# Altium schematic sheet PERIPHERAL_PORT_IO.SchDoc
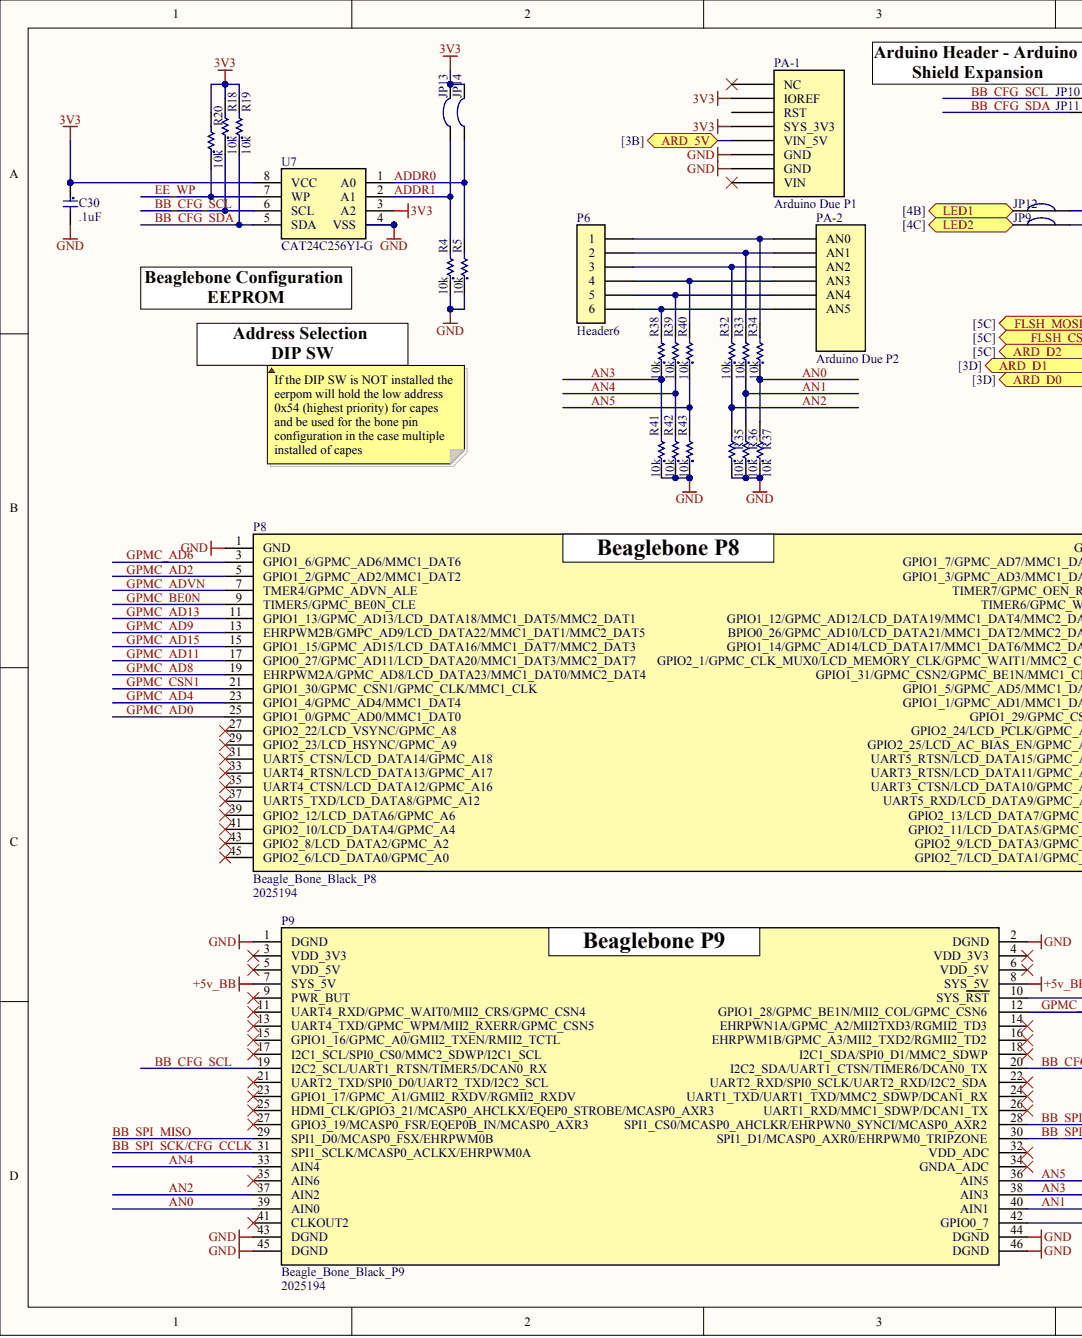
[diagram p0: PERIPHERAL_PORT_IO.SchDoc, left]
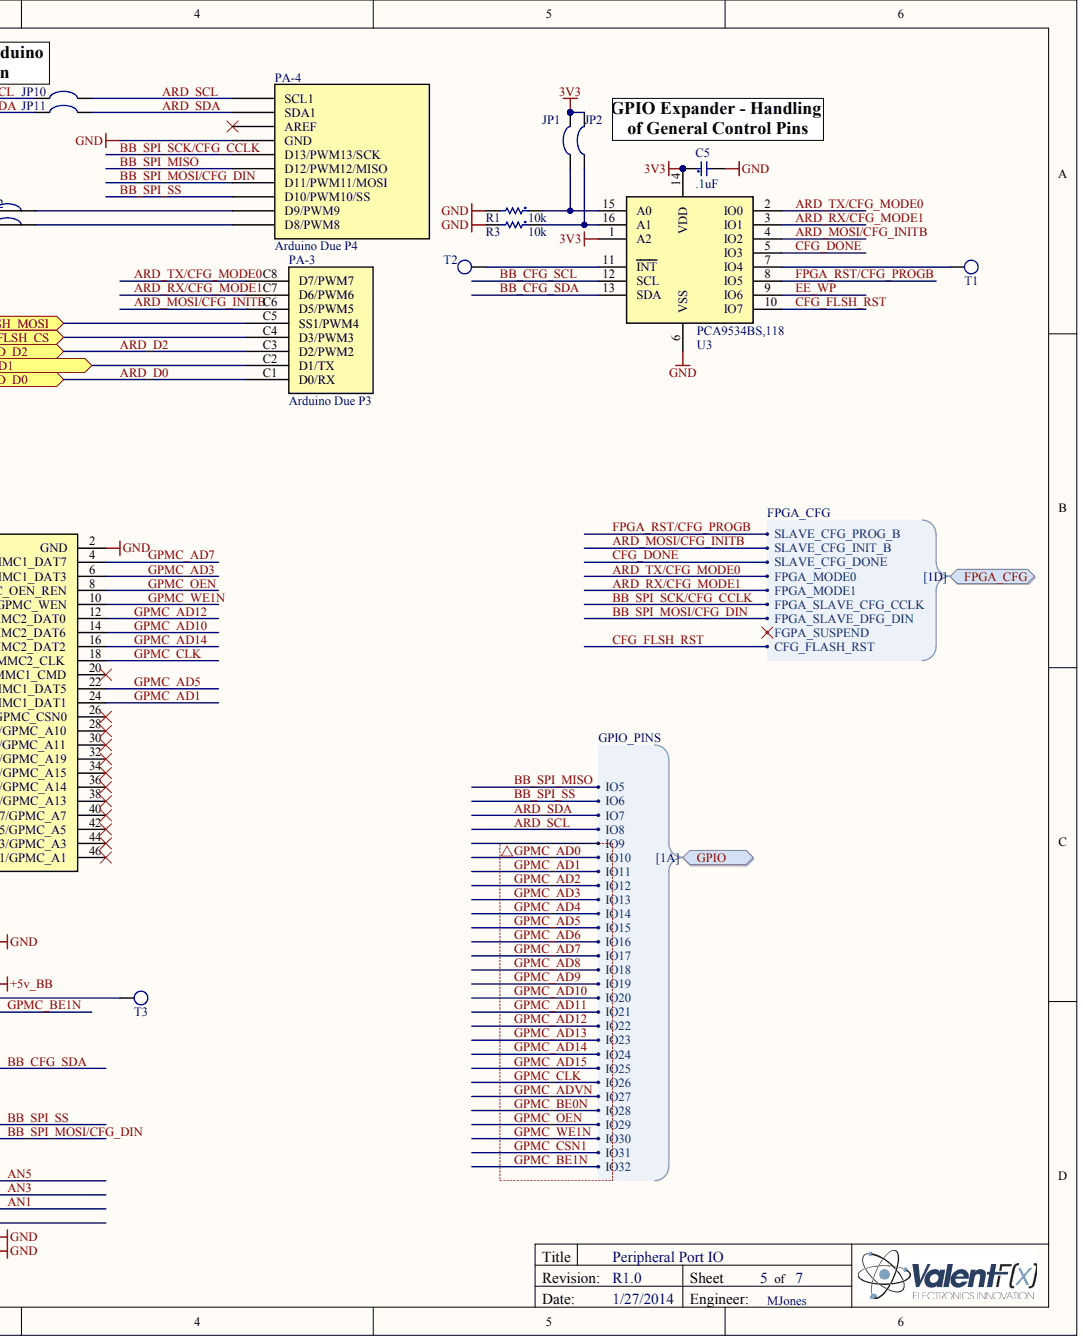
[diagram p1: PERIPHERAL_PORT_IO.SchDoc, right]

## Parts
C5  .1uF
C30  .1uF
JP1  Solder Jumper  (value not drawn)
JP2  Solder Jumper  (value not drawn)
JP9  Solder Jumper-NC  (value not drawn)
JP10  Solder Jumper  (value not drawn)
JP11  Solder Jumper  (value not drawn)
JP12  Solder Jumper-NC  (value not drawn)
JP13  Solder Jumper  (value not drawn)
JP14  Solder Jumper  (value not drawn)
P6  Header6
P8  Supplier Part Number 1: 2025194  (hidden comment: Beagle_Bone_Black_P8)
P9  Supplier Part Number 1: 2025194  (hidden comment: Beagle_Bone_Black_P9)
PA-1  Arduino Due P1
PA-2  Arduino Due P2
PA-3  Arduino Due P3
PA-4  Arduino Due P4
R1  10k
R3  10k
R4  10k
R5  10k
R18  10k
R19  10k
R20  10k
R32  10k
R33  10k
R34  10k
R35  10k
R36  10k
R37  10k
R38  10k
R39  10k
R40  10k
R41  10k
R42  10k
R43  10k
T1  Test Point  (value not drawn)
T2  Test Point  (value not drawn)
T3  Test Point  (value not drawn)
U3  PCA9534BS,118
U7  CAT24C256YI-G

## Ports
ARD_5V, ARD_D0, ARD_D1, ARD_D2, FLSH_CS, FLSH_MOSI, FPGA_CFG, FPGA_CFG.CFG_FLASH_RST, FPGA_CFG.FGPA_SUSPEND, FPGA_CFG.FPGA_MODE0, FPGA_CFG.FPGA_MODE1, FPGA_CFG.FPGA_SLAVE_CFG_CCLK, FPGA_CFG.FPGA_SLAVE_DFG_DIN, FPGA_CFG.SLAVE_CFG_DONE, FPGA_CFG.SLAVE_CFG_INIT_B, FPGA_CFG.SLAVE_CFG_PROG_B, GPIO, GPIO.IO5, GPIO.IO6, GPIO.IO7, GPIO.IO8, GPIO.IO9, GPIO.IO10, GPIO.IO11, GPIO.IO12, GPIO.IO13, GPIO.IO14, GPIO.IO15, GPIO.IO16, GPIO.IO17, GPIO.IO18, GPIO.IO19, GPIO.IO20, GPIO.IO21, GPIO.IO22, GPIO.IO23, GPIO.IO24, GPIO.IO25, GPIO.IO26, GPIO.IO27, GPIO.IO28, GPIO.IO29, GPIO.IO30, GPIO.IO31, GPIO.IO32, LED1, LED2

## Nets
3V3: C5.1 C30.1 JP1.2 JP2.2 JP13.2 JP14.2 PA-1.A2(IOREF) PA-1.A4(SYS_3V3) R18.2 R19.2 R20.2 U3.1(A2) U3.14(VDD) U7.3(A2) U7.8(VCC)
+5V_BB: P9.7(SYS_5V) P9.8(SYS_5V)
GND: C5.2 C30.2 P8.1(GND) P8.2(GND) P9.1(DGND) P9.2(DGND) P9.43(DGND) P9.44(DGND) P9.45(DGND) P9.46(DGND) PA-1.A6(GND) PA-1.A7(GND) PA-4.D7(GND) R1.2 R3.2 R4.1 R5.1 R35.1 R36.1 R37.1 R41.1 R42.1 R43.1 U3.6(VSS) U7.4(VSS)
ADDR0: JP14.1 R5.2 U7.1(A0)
ADDR1: JP13.1 R4.2 U7.2(A1)
AN0: P9.39(AIN0) R34.1 R37.2
AN1: P9.40(AIN1) R33.1 R36.2
AN2: P9.37(AIN2) R32.1 R35.2
AN3: P9.38(AIN3) R38.1 R41.2
AN4: P9.33(AIN4) R39.1 R42.2
AN5: P9.36(AIN5) R40.1 R43.2
ARD_5V: PA-1.A5(VIN_5V) ; port ARD_5V
ARD_D0: PA-3.C1(D0/RX) ; port ARD_D0
ARD_D1: PA-3.C2(D1/TX) ; port ARD_D1
ARD_D2: PA-3.C3(D2/PWM2) ; port ARD_D2
ARD_MOSI/CFG_INITB: PA-3.C6(D5/PWM5) U3.4(IO2) ; port FPGA_CFG
ARD_RX/CFG_MODE1: PA-3.C7(D6/PWM6) U3.3(IO1) ; port FPGA_CFG
ARD_SCL: JP10.2 PA-4.D10(SCL1) ; port GPIO
ARD_SDA: JP11.2 PA-4.D9(SDA1) ; port GPIO
ARD_TX/CFG_MODE0: PA-3.C8(D7/PWM7) U3.2(IO0) ; port FPGA_CFG
BB_CFG_SCL: JP10.1 P9.19(I2C2_SCL/UART1_RTSN/TIMER5/DCAN0_RX) R18.1 U3.12(SCL) U7.6(SCL)
BB_CFG_SDA: JP11.1 P9.20(I2C2_SDA/UART1_CTSN/TIMER6/DCAN0_TX) R19.1 U3.13(SDA) U7.5(SDA)
BB_SPI_MISO: P9.29(SPI1_D0/MCASP0_FSX/EHRPWM0B) PA-4.D5(D12/PWM12/MISO) ; port GPIO
BB_SPI_MOSI/CFG_DIN: P9.30(SPI1_D1/MCASP0_AXR0/EHRPWM0_TRIPZONE) PA-4.D4(D11/PWM11/MOSI) ; port FPGA_CFG
BB_SPI_SCK/CFG_CCLK: P9.31(SPI1_SCLK/MCASP0_ACLKX/EHRPWM0A) PA-4.D6(D13/PWM13/SCK) ; port FPGA_CFG
BB_SPI_SS: P9.28(SPI1_CS0/MCASP0_AHCLKR/EHRPWN0_SYNCI/MCASP0_AXR2) PA-4.D3(D10/PWM10/SS) ; port GPIO
CFG_DONE: U3.5(IO3) ; port FPGA_CFG
CFG_FLSH_RST: U3.10(IO7) ; port FPGA_CFG
EE_WP: R20.1 U3.9(IO6) U7.7(WP)
FLSH_CS: PA-3.C4(D3/PWM3) ; port FLSH_CS
FLSH_MOSI: PA-3.C5(SS1/PWM4) ; port FLSH_MOSI
FPGA_RST/CFG_PROGB: U3.8(IO5) ; port FPGA_CFG
GPMC_AD0: P8.25(GPIO1_0/GPMC_AD0/MMC1_DAT0) ; port GPIO
GPMC_AD1: P8.24(GPIO1_1/GPMC_AD1/MMC1_DAT1) ; port GPIO
GPMC_AD2: P8.5(GPIO1_2/GPMC_AD2/MMC1_DAT2) ; port GPIO
GPMC_AD3: P8.6(GPIO1_3/GPMC_AD3/MMC1_DAT3) ; port GPIO
GPMC_AD4: P8.23(GPIO1_4/GPMC_AD4/MMC1_DAT4) ; port GPIO
GPMC_AD5: P8.22(GPIO1_5/GPMC_AD5/MMC1_DAT5) ; port GPIO
GPMC_AD6: P8.3(GPIO1_6/GPMC_AD6/MMC1_DAT6) ; port GPIO
GPMC_AD7: P8.4(GPIO1_7/GPMC_AD7/MMC1_DAT7) ; port GPIO
GPMC_AD8: P8.19(EHRPWM2A/GPMC_AD8/LCD_DATA23/MMC1_DAT0/MMC2_DAT4) ; port GPIO
GPMC_AD9: P8.13(EHRPWM2B/GMPC_AD9/LCD_DATA22/MMC1_DAT1/MMC2_DAT5) ; port GPIO
GPMC_AD10: P8.14(BPIO0_26/GPMC_AD10/LCD_DATA21/MMC1_DAT2/MMC2_DAT6) ; port GPIO
GPMC_AD11: P8.17(GPIO0_27/GPMC_AD11/LCD_DATA20/MMC1_DAT3/MMC2_DAT7) ; port GPIO
GPMC_AD12: P8.12(GPIO1_12/GPMC_AD12/LCD_DATA19/MMC1_DAT4/MMC2_DAT0) ; port GPIO
GPMC_AD13: P8.11(GPIO1_13/GPMC_AD13/LCD_DATA18/MMC1_DAT5/MMC2_DAT1) ; port GPIO
GPMC_AD14: P8.16(GPIO1_14/GPMC_AD14/LCD_DATA17/MMC1_DAT6/MMC2_DAT2) ; port GPIO
GPMC_AD15: P8.15(GPIO1_15/GPMC_AD15/LCD_DATA16/MMC1_DAT7/MMC2_DAT3) ; port GPIO
GPMC_ADVN: P8.7(TMER4/GPMC_ADVN_ALE) ; port GPIO
GPMC_BE0N: P8.9(TIMER5/GPMC_BE0N_CLE) ; port GPIO
GPMC_BE1N: P9.12(GPIO1_28/GPMC_BE1N/MII2_COL/GPMC_CSN6) ; port GPIO
GPMC_CLK: P8.18(GPIO2_1/GPMC_CLK_MUX0/LCD_MEMORY_CLK/GPMC_WAIT1/MMC2_CLK) ; port GPIO
GPMC_CSN1: P8.21(GPIO1_30/GPMC_CSN1/GPMC_CLK/MMC1_CLK) ; port GPIO
GPMC_OEN: P8.8(TIMER7/GPMC_OEN_REN) ; port GPIO
GPMC_WE1N: P8.10(TIMER6/GPMC_WEN) ; port GPIO
LED1: JP12.1 ; port LED1
LED2: JP9.1 ; port LED2
NetJP1_1: JP1.1 R1.1 U3.15(A0)
NetJP2_1: JP2.1 R3.1 U3.16(A1)
NetJP9_2: JP9.2 PA-4.D1(D8/PWM8)
NetJP12_2: JP12.2 PA-4.D2(D9/PWM9)
NetP6_1: P6.1 PA-2.B1(AN0) R34.2
NetP6_2: P6.2 PA-2.B2(AN1) R33.2
NetP6_3: P6.3 PA-2.B3(AN2) R32.2
NetP6_4: P6.4 PA-2.B4(AN3) R40.2
NetP6_5: P6.5 PA-2.B5(AN4) R39.2
NetP6_6: P6.6 PA-2.B6(AN5) R38.2
NetP9_10: P9.10(SYS_RST) T3.1
NetT1_1: T1.1 U3.7(IO4)
NetT2_1: T2.1 U3.11(INT)
N00220: ; port FPGA_CFG
N00221: ; port GPIO

Not connected: P8 20(GPIO1_31/GPMC_CSN2/GPMC_BE1N/MMC1_CMD) 26(GPIO1_29/GPMC_CSN0) 27(GPIO2_22/LCD_VSYNC/GPMC_A8) 28(GPIO2_24/LCD_PCLK/GPMC_A10) 29(GPIO2_23/LCD_HSYNC/GPMC_A9) 30(GPIO2_25/LCD_AC_BIAS_EN/GPMC_A11) 31(UART5_CTSN/LCD_DATA14/GPMC_A18) 32(UART5_RTSN/LCD_DATA15/GPMC_A19) 33(UART4_RTSN/LCD_DATA13/GPMC_A17) 34(UART3_RTSN/LCD_DATA11/GPMC_A15) 35(UART4_CTSN/LCD_DATA12/GPMC_A16) 36(UART3_CTSN/LCD_DATA10/GPMC_A14) 37(UART5_TXD/LCD_DATA8/GPMC_A12) 38(UART5_RXD/LCD_DATA9/GPMC_A13) 39(GPIO2_12/LCD_DATA6/GPMC_A6) 40(GPIO2_13/LCD_DATA7/GPMC_A7) 41(GPIO2_10/LCD_DATA4/GPMC_A4) 42(GPIO2_11/LCD_DATA5/GPMC_A5) 43(GPIO2_8/LCD_DATA2/GPMC_A2) 44(GPIO2_9/LCD_DATA3/GPMC_A3) 45(GPIO2_6/LCD_DATA0/GPMC_A0) 46(GPIO2_7/LCD_DATA1/GPMC_A1); P9 3(VDD_3V3) 4(VDD_3V3) 5(VDD_5V) 6(VDD_5V) 9(PWR_BUT) 11(UART4_RXD/GPMC_WAIT0/MII2_CRS/GPMC_CSN4) 13(UART4_TXD/GPMC_WPM/MII2_RXERR/GPMC_CSN5) 14(EHRPWN1A/GPMC_A2/MII2TXD3/RGMII2_TD3) 15(GPIO1_16/GPMC_A0/GMII2_TXEN/RMII2_TCTL) 16(EHRPWM1B/GPMC_A3/MII2_TXD2/RGMII2_TD2) 17(I2C1_SCL/SPI0_CS0/MMC2_SDWP/I2C1_SCL) 18(I2C1_SDA/SPI0_D1/MMC2_SDWP) 21(UART2_TXD/SPI0_D0/UART2_TXD/I2C2_SCL) 22(UART2_RXD/SPI0_SCLK/UART2_RXD/I2C2_SDA) 23(GPIO1_17/GPMC_A1/GMII2_RXDV/RGMII2_RXDV) 24(UART1_TXD/UART1_TXD/MMC2_SDWP/DCAN1_RX) 25(HDMI_CLK/GPIO3_21/MCASP0_AHCLKX/EQEP0_STROBE/MCASP0_AXR3) 26(UART1_RXD/MMC1_SDWP/DCAN1_TX) 27(GPIO3_19/MCASP0_FSR/EQEP0B_IN/MCASP0_AXR3) 32(VDD_ADC) 34(GNDA_ADC) 35(AIN6) 41(CLKOUT2) 42(GPIO0_7); PA-1 A1(NC) A3(RST) A8(VIN); PA-4 D8(AREF)

## Library data of the parts
C5 C30 = (no symbol name): Manufacturer: Murata; Manufacturer Part Number: GRM155R61C104KA88D; Capacitance: 0.1 uF; Tolerance: 10 %; Voltage Rating: 16 Volts; Temperature Coefficient / Code: X5R; Value: .1uF; Supplier 1: Mouser; Supplier Part Number 1: 81-GRM155R61C104KA8D; RoHS: RoHS Compliant By Exemption; Category: Multilayer Ceramic Capacitors (MLCC) - SMD/SMT; Stock 1: 478408; Pricing 1: 1=0.122, 50=0.034, 100=0.026, 500=0.014, 1000=0.009, 10000=0.005, 20000=0.004 (AUD); Package / Case: 0402 (1005 metric); Packaging: Reel; ComponentLink1URL: http://www.murata.com/products/catalog/pdf/c02e.pdf; ComponentLink1Description: http://www.murata.com/products/catalog/pdf/c02e.pdf; Dimensions: 0.5 mm W x 1 mm L x 0.5 mm H; Operating Temperature Range: - 55 C to + 85 C; Product: General Type MLCCs; Series: GRM; Termination Style: SMD/SMT; Product Category: Low & Medium Capacitance SMD Ceramic Chip Capacitors; Standard Pack Qty: 10000; RoHS - Mouser: E; Minimum Order Quantity: 1; Reeling: True
P8 P9 = (no symbol name): Manufacturer: SAMTEC; Manufacturer Part Number: TSW-123-07-F-D; Pitch Spacing: 2.54; No. of Rows: 2; No. of Contacts: 46; Gender: Header; Contact Termination: Through Hole Vertical; SVHC: No SVHC (20-Jun-2013); Connector Type: Board to Board; : TSW-113-F-D; Supplier 1: Farnell; RoHS: YES; Stock 1: 100; Pricing 1: 5=3.7, 50=2.55, 100=1.99, 250=1.63, 500=1.48, 2500=1.2 (GBP); Series: TSW; Contact Plating: Gold; Contact Material: Phosphor Bronze; Row Pitch: 2.54; ComponentLink1URL: http://www.farnell.com/datasheets/1701645.pdf; ComponentLink1Description: http://www.farnell.com/datasheets/1701645.pdf; ComponentLink2URL: http://www.samtec.com/ftppub/pdf/TSW_TH.PDF; ComponentLink2Description: http://www.samtec.com/ftppub/pdf/TSW_TH.PDF; ComponentLink3URL: http://www.farnell.com/cad/1528871.zip; ComponentLink3Description: http://www.farnell.com/cad/1528871.zip
  P8: Value: Beagle_Bone_Black_P8
  P9: Value: Beagle_Bone_Black_P9
PA-1 PA-3 = (no symbol name): Manufacturer: Sullins Connector Solutions; Manufacturer Part Number: PPPC081LFBN-RC; Connector Type: Header; Number of Positions: 8; Number of Positions Loaded: All; Pitch: 0.100" (2.54mm); Supplier 1: Digi-Key; RoHS: RoHS Compliant; Category: Connectors, Interconnects; Stock 1: 30245; Pricing 1: 1=0.89, 10=0.69, 25=0.6436, 50=0.585, 100=0.5265, 250=0.4446, 500=0.4212, 1000=0.3744, 2500=0.31122 (USD); Packaging: Bulk; Contact Type: Female Socket; Number of Rows: 1; Row Spacing: -; Mounting Type: Through Hole; Termination: Solder; Fastening Type: -; Features: -; Contact Finish: Gold; Contact Finish Thickness: Flash; Color: Black; ComponentLink1URL: http://www.sullinscorp.com/drawings/78_P(N)PxCxxxLFBN-RC,_10492-G.pdf; ComponentLink1Description: http://www.sullinscorp.com/drawings/78_P(N)PxCxxxLFBN-RC,_10492-G.pdf; Supplier Part Number 1: S7041-ND
PA-2 = (no symbol name): Manufacturer: Sullins Connector Solutions; Manufacturer Part Number: PPPC061LFBN-RC; Connector Type: Header; Number of Positions: 6; Number of Positions Loaded: All; Pitch: 0.100" (2.54mm); Supplier 1: Digi-Key; RoHS: RoHS Compliant; Category: Connectors, Interconnects; Stock 1: 9213; Pricing 1: 1=0.7, 10=0.546, 25=0.5088, 50=0.4626, 100=0.4163, 250=0.35152, 500=0.333, 1000=0.296, 2500=0.24605 (USD); Packaging: Bulk; Contact Type: Female Socket; Number of Rows: 1; Row Spacing: -; Mounting Type: Through Hole; Termination: Solder; Fastening Type: -; Features: -; Contact Finish: Gold; Contact Finish Thickness: Flash; Color: Black; ComponentLink1URL: http://www.sullinscorp.com/drawings/78_P(N)PxCxxxLFBN-RC,_10492-G.pdf; ComponentLink1Description: http://www.sullinscorp.com/drawings/78_P(N)PxCxxxLFBN-RC,_10492-G.pdf; Supplier Part Number 1: S7039-ND
PA-4 = (no symbol name): Manufacturer: Sullins Connector Solutions; Manufacturer Part Number: PPPC101LFBN-RC; Connector Type: Header; Number of Positions: 10; Number of Positions Loaded: All; Pitch: 0.100" (2.54mm); Supplier 1: Digi-Key; RoHS: RoHS Compliant; Category: Connectors, Interconnects; Stock 1: 5570; Pricing 1: 1=0.84, 10=0.699, 25=0.6476, 50=0.5828, 100=0.518, 250=0.45324, 500=0.4144, 1000=0.37555, 2500=0.3367 (USD); Packaging: Bulk; Contact Type: Female Socket; Number of Rows: 1; Row Spacing: -; Mounting Type: Through Hole; Termination: Solder; Fastening Type: -; Features: -; Contact Finish: Gold; Contact Finish Thickness: Flash; Color: Black; ComponentLink1URL: http://www.sullinscorp.com/drawings/78_P(N)PxCxxxLFBN-RC,_10492-G.pdf; ComponentLink1Description: http://www.sullinscorp.com/drawings/78_P(N)PxCxxxLFBN-RC,_10492-G.pdf; Supplier Part Number 1: S7043-ND
R1 R3-R5 R18-R20 R32-R43 = (no symbol name): Manufacturer: Vishay Dale; Manufacturer Part Number: CRCW060310K0FKEA; Power (Watts): 0.1W, 1/10W; Temperature Coefficient: ±100ppm/°C; Tolerance: ±1%; Value: 10k; Supplier 1: Digi-Key; RoHS: RoHS Compliant; Category: Resistors; Stock 1: 997856; Pricing 1: 10=0.081, 50=0.0436, 200=0.0251, 1000=0.01711 (USD); Resistance (Ohms): 10K; Composition: Thick Film; Features: -; Size / Dimension: 0.061" L x 0.033" W (1.55mm x 0.85mm); Height: 0.020" (0.50mm); Packaging: Cut Tape (CT); Package / Case: 0603 (1608 Metric); ComponentLink1URL: http://www.vishay.com/doc?20035; ComponentLink1Description: http://www.vishay.com/doc?20035; Supplier Part Number 1: 541-10.0KHCT-ND; Number of Terminations: 2
U3 = (no symbol name): Manufacturer: NXP Semiconductors; Output Current: 50 mA; Logic Family: PCA; Power Dissipation: 200 mW; Operating Supply Voltage: 2.3 V to 5.5 V; Supplier 1: Mouser; Manufacturer Part Number: PCA9534BS,118; RoHS: RoHS Compliant; Category: I/O Expanders, Repeaters & Hubs; Stock 1: 6089; Pricing 1: 1=1.27, 25=1.16, 100=1.09, 250=1.04, 500=1.00, 1000=0.960, 3000=0.933, 6000=0.899, 12000=0.875 (AUD); Operating Temperature Range: - 40 C to + 85 C; Package / Case: HVQFN-16; Packaging: Reel; Mounting Style: SMD/SMT; Product Category: I/O Expanders; Standard Pack Qty: 6000; RoHS - Mouser: Y; Minimum Order Quantity: 1; Reeling: True; ComponentLink1URL: http://www.nxp.com/documents/data_sheet/PCA9534.pdf; ComponentLink1Description: http://www.nxp.com/documents/data_sheet/PCA9534.pdf; Supplier Part Number 1: 771-PCA9534BS118; Value: PCA9534BS,118
U7 = (no symbol name): Manufacturer: ON Semiconductor; Format - Memory: EEPROMs - Serial; Memory Type: EEPROM; Memory Size: 256K (32K x 8); Speed: 400kHz; Interface: I²C, 2-Wire Serial; Voltage - Supply: 1.8 V ~ 5.5 V; Supplier 1: Digi-Key; Manufacturer Part Number: CAT24C256YI-G; RoHS: RoHS Compliant; Category: Integrated Circuits (ICs); Stock 1: 840; Pricing 1: 1=0.97, 10=0.845, 25=0.7488, 100=0.6521, 250=0.56752, 500=0.483, 1000=0.3864, 2500=0.35018, 5000=0.32603 (USD); Operating Temperature: -40°C ~ 85°C; Package / Case: 8-TSSOP (0.173", 4.40mm Width); Supplier Device Package: 8-TSSOP; Packaging: Tube; ComponentLink1URL: http://www.onsemi.com/pub_link/Collateral/CAT24C256-D.PDF; ComponentLink1Description: http://www.onsemi.com/pub_link/Collateral/CAT24C256-D.PDF; Supplier Part Number 1: CAT24C256YI-GOS-ND; Value: CAT24C256YI-G
JP1 JP2 JP9-JP14 P6 T1-T3 = (no symbol name)
  JP1 JP2 JP9-JP14: Manufacturer: n/a; Manufacturer Part Number: n/a; Source: n/a; Source Part Number: n/a; ROHS Certificate: n/a
